# Altium schematic sheet Boost Converter 6V.SchDoc
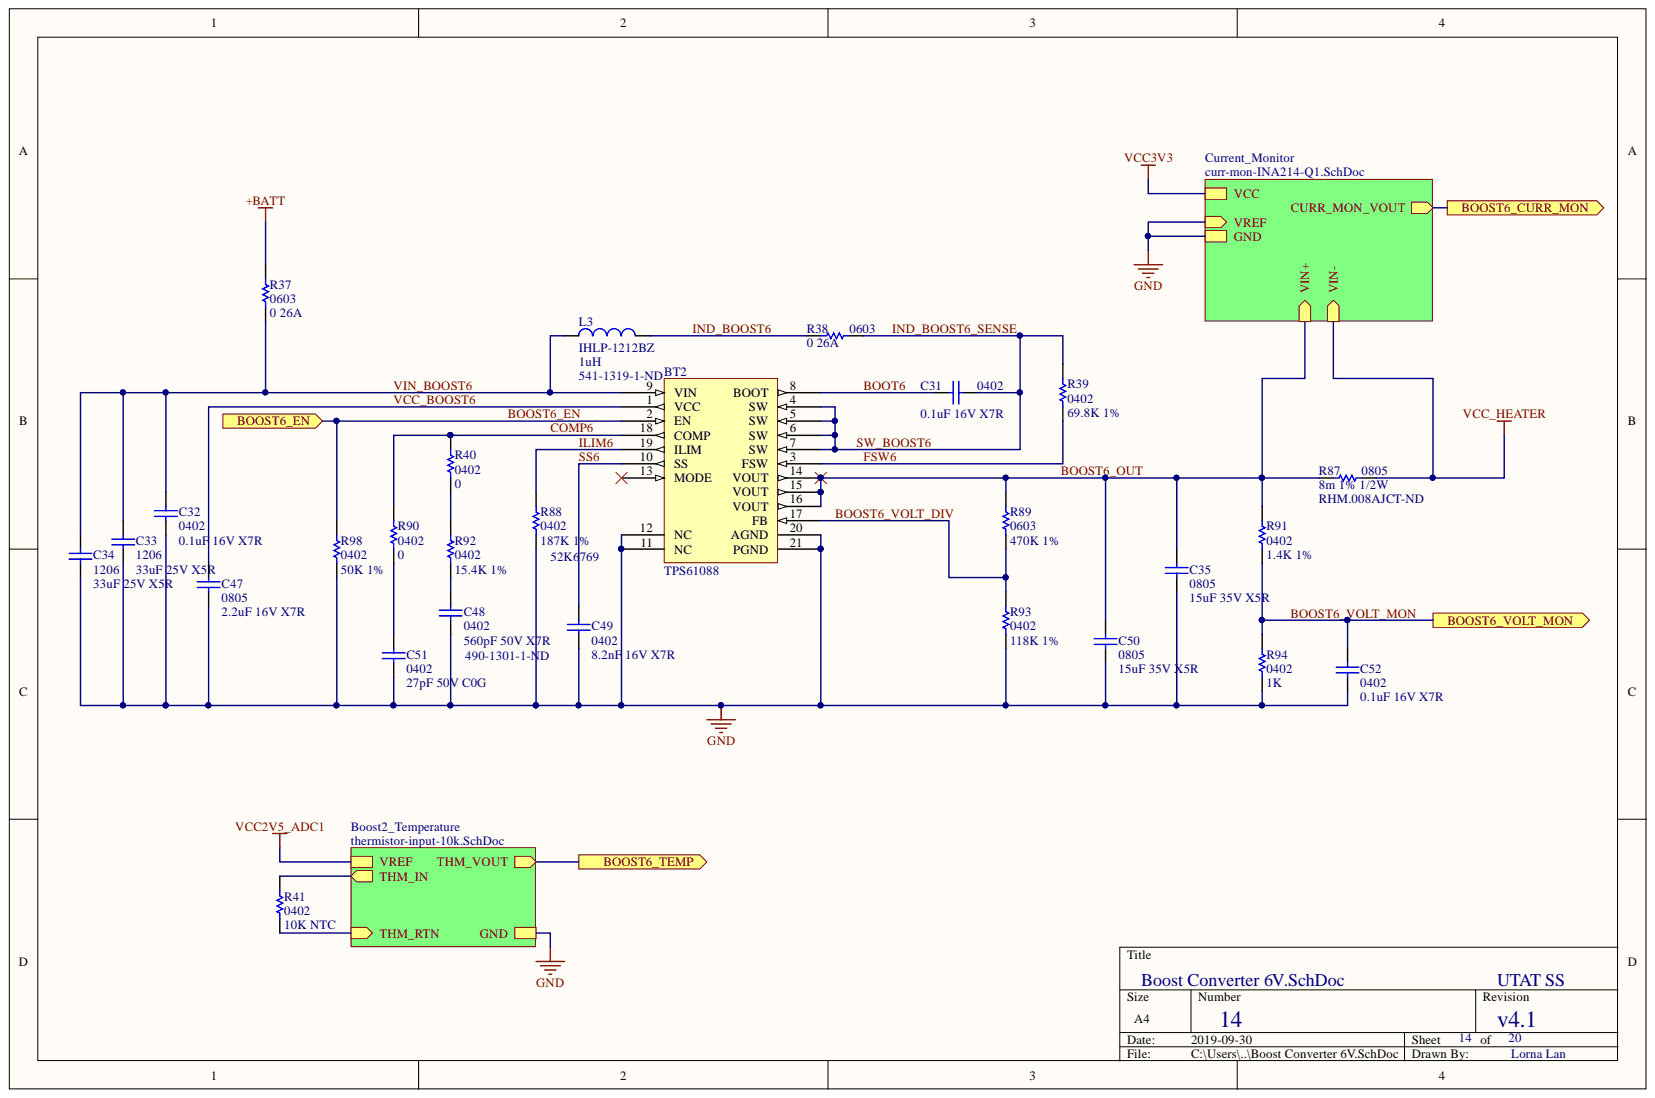
[diagram p0: Boost Converter 6V.SchDoc]

## Parts
BT2  TPS61088  [QFN50P450X350X100-21N]
C31  0402  value 0.1uF 16V X7R  [CAP0402R]
C32  0402  value 0.1uF 16V X7R  [CAP0402R]
C33  1206  value 33uF 25V X5R  [CAP1206R]
C34  1206  value 33uF 25V X5R  [CAP1206R]
C35  0805  value 15uF 35V X5R  [CAP0805R]
C47  0805  value 2.2uF 16V X7R  [CAP0805R]
C48  0402  value 560pF 50V X7R  Supplier Part Number 1: 490-1301-1-ND  [CAP0402R]
C49  0402  value 8.2nF 16V X7R  [CAP0402R]
C50  0805  value 15uF 35V X5R  [CAP0805R]
C51  0402  value 27pF 50V C0G  [CAP0402R]
C52  0402  value 0.1uF 16V X7R  [CAP0402R]
L3  IHLP-1212BZ  Inductance: 1uH  [IHLP-1212BZ]
R37  0603  value 0 26A  [RES0603R]
R38  0603  value 0 26A  [RES0603R]
R39  0402  value 69.8K 1%  [RES0402R]
R40  0402  value 0  [RES0402R]
R41  0402  value 10K NTC  [RES0402R]
R87  0805  value 8m 1% 1/2W  Supplier Part Number 1: RHM.008AJCT-ND  [RES0805R]
R88  0402  value 187K 1%  Supplier Part Number 1: 52K6769  [RES0402R]
R89  0603  value 470K 1%  [RES0603R]
R90  0402  value 0  [RES0402R]
R91  0402  value 1.4K 1%  [RES0402R]
R92  0402  value 15.4K 1%  [RES0402R]
R93  0402  value 118K 1%  [RES0402R]
R94  0402  value 1K  [RES0402R]
R98  0402  value 50K 1%  [RES0402R]

## Ports
BOOST6_CURR_MON, BOOST6_EN, BOOST6_TEMP, BOOST6_VOLT_MON

## Nets
+BATT: R37.2
GND: BT2.11(NC) BT2.12(NC) BT2.20(AGND) BT2.21(PGND) C32.1 C33.1 C34.1 C35.1 C47.1 C48.1 C49.1 C50.1 C51.1 C52.1 R88.1 R93.1 R94.1 R98.1
VCC_HEATER: R87.2
BOOST6_EN: BT2.2(EN) R98.2 ; port BOOST6_EN
BOOST6_OUT: BT2.14(VOUT) BT2.15(VOUT) BT2.16(VOUT) C35.2 C50.2 R87.1 R89.2 R91.2
BOOST6_VOLT_DIV: BT2.17(FB) R89.1 R93.2
BOOST6_VOLT_MON: C52.2 R91.1 R94.2 ; port BOOST6_VOLT_MON
BOOT6: BT2.8(BOOT) C31.1
COMP6: BT2.18(COMP) R40.2 R90.2
FSW6: BT2.3(FSW) R39.1
ILIM6: BT2.19(ILIM) R88.2
IND_BOOST6: L3.2 R38.1
IND_BOOST6_SENSE: BT2.4(SW) BT2.5(SW) BT2.6(SW) BT2.7(SW) C31.2 R38.2 R39.2
SS6: BT2.10(SS) C49.2
VCC_BOOST6: BT2.1(VCC) C47.2
VIN_BOOST6: BT2.9(VIN) C32.2 C33.2 C34.2 L3.1 R37.1
NetBT2_13: BT2.13(MODE)
NetC48_2: C48.2 R92.1
NetC51_2: C51.2 R90.1
NetR40_1: R40.1 R92.2
NetR41_1: R41.1
NetR41_2: R41.2
N00341: ; port BOOST6_CURR_MON
N00342: ; port BOOST6_TEMP
VCC2V5_ADC1:
VCC3V3:

## Library data of the parts
BT2 = TPS61088: Boost converter; Component Kind: Standard; Library Name: EPS.SchLib; Supplier 1: Digi-Key; Supplier Part Number 1: 296-42129-1-ND
C31 C49 C52 = CAP0402: 0402 capacitor; Component Kind: Standard; Signal Integrity: Cap; Simulation: CAP
  C49: Library Name: CAP0402.SchLib; Supplier 1: Digi-Key; Supplier Part Number 1: 490-6338-1-ND
  C31 C52: Library Name: heron-general.IntLib
C32 = CAP0402: 0402 capacitor; Value: 0.1uF 16V X7R; Component Kind: Standard; Signal Integrity: Cap; Simulation: CAP; Library Name: heron-general.IntLib
C33 C34 = CAP1206: 1206 capacitor; Value: 33uF 25V X5R; Component Kind: Standard; Signal Integrity: Cap; Simulation: CAP; Supplier 1: Digi-Key; Supplier Part Number 1: 445-8046-1-ND; Library Name: heron-general.IntLib
C35 = CAP0805: 0805 capacitor; Value: 15uF 35V X5R; Component Kind: Standard; Signal Integrity: Cap; Simulation: CAP; Supplier 1: Mouser; Supplier Part Number 1: 810-C2012X5R1V156M1C; Library Name: heron-general.IntLib
C47 C50 = CAP0805: 0805 capacitor; Component Kind: Standard; Signal Integrity: Cap; Simulation: CAP; Library Name: heron-general.IntLib
  C47: Supplier 1: Digi-Key; Supplier Part Number 1: 490-3906-1-ND
  C50: Supplier 1: Mouser; Supplier Part Number 1: 810-C2012X5R1V156M1C
C48 = CAP0402: 0402 capacitor; Value: 560pF 50V X7R; Component Kind: Standard; Signal Integrity: Cap; Simulation: CAP; Supplier 1: Digi-Key; Library Name: heron-general.IntLib
C51 = CAP0402: 0402 capacitor; Value: 27pF 50V C0G; Component Kind: Standard; Signal Integrity: Cap; Simulation: CAP; Supplier 1: Digi-Key; Supplier Part Number 1: 490-5869-1-ND; Library Name: heron-general.IntLib
L3 = IND_IHLP-1212BZ: 1µH Shielded Molded Inductor 5A 24mOhm Max Nonstandard; Manufacturer: Vishay Dale; Manufacturer Part Number: IHLP1212BZER1R0M11; Core Material: Metal,Composite; DC Resistance (DCR): 24mR; Inductance Tolerance: 20%; Saturation Current: 4.5A; Self Resonant Frequency: 75MHz; Test Frequency: 100kHz; Case/Package: 1212; Case Code (Imperial): 1212; Case Code (Metric): 3630; Component Kind: Standard; ComponentLink1Description: http://www.mouser.com/ds/2/427/lp12bz11-244380.pdf?src-supplier=Mouser; ComponentLink1URL: http://www.mouser.com/ds/2/427/lp12bz11-244380.pdf?src-supplier=Mouser; ComponentLink2Description: http://www.vishay.com/docs/34289/lp12bz11.pdf?src-supplier=Digi-Key; ComponentLink2URL: http://www.vishay.com/docs/34289/lp12bz11.pdf?src-supplier=Digi-Key; ComponentLink3Description: http://www.vishay.com/docs/34289/lp12bz11.pdf?src-supplier=Avnet; ComponentLink3URL: http://www.vishay.com/docs/34289/lp12bz11.pdf?src-supplier=Avnet; ComponentLink4Description: http://www.farnell.com/datasheets/652599.pdf?src-supplier=Element14; ComponentLink4URL: http://www.farnell.com/datasheets/652599.pdf?src-supplier=Element14; ComponentLink5Description: http://www.vishay.com/docs/34289/lp12bz11.pdf?src-supplier=Avnet+Europe; ComponentLink5URL: http://www.vishay.com/docs/34289/lp12bz11.pdf?src-supplier=Avnet+Europe; ComponentLink6Description: https://www.verical.com/server-webapp/html/getDatasheet?partID=180380&src-supplier=Verical; ComponentLink6URL: https://www.verical.com/server-webapp/html/getDatasheet?partID=180380&src-supplier=Verical; Contact Plating: Tin; Current Rating: 5A; Depth: 3.2mm; Height: 2mm; Height - Seated (Max): 0.079inch; Lead Free: Lead Free; Length: 3.6mm; Max DC Current: 5A; Max Operating Temperature: 125°C; Military Standard: Not; Min Operating Temperature: -55°C; Mount: Surface Mount; Number of Pins: 2; Package Quantity: 3000; Packaging: Tape and Reel; Pricing 1: 1=1.45, 10=1.346, 100=0.9729, 500=0.7452, 1000=0.6624 (USD); Radiation Hardening: No; REACH SVHC: Unknown; RMS Current (Irms): 5A; Shielding: Shielded; Stock 1: 196362; Supplier 1: Digi-Key; Supplier Part Number 1: 541-1319-1-ND; Termination: SMD/SMT; Tolerance: 20%; Width: 0.118inch; Library Name: heron-general.IntLib
R37 R38 R89 = RES0603: 0603 resistor; Component Kind: Standard; Signal Integrity: Res; Simulation: RESISTOR; Supplier 1: Digi-Key
  R37 R38: Supplier Part Number 1: 2019-TLRZ1JTTDCT-ND; Library Name: heron-general.IntLib
  R89: Library Name: RES0603.SchLib; Supplier Part Number 1: 311-470KHRCT-ND
R39 R41 R88 R91-R94 = RES0402: 0402 resistor; Component Kind: Standard; Signal Integrity: Res; Simulation: RESISTOR
  R39: Supplier 1: Digi-Key; Supplier Part Number 1: 541-69.8KLCT-ND
  R88: Supplier 1: Newark
  R91: Library Name: RES0402.SchLib
  R93: Library Name: RES0402.SchLib; Supplier 1: Digi-Key; Supplier Part Number 1: 541-118KLCT-ND
  R94: Library Name: RES0402.SchLib; Supplier 1: Digi-Key; Supplier Part Number 1: YAG1234CT-ND
  R39 R41 R88 R92: Library Name: heron-general.IntLib
R40 R90 = RES0402: 0402 resistor; Manufacturer: Panasonic; Manufacturer Part Number: ERJ-2GE0R00X; Max Power Dissipation: 100mW; Resistance: 0mR; Temperature Coefficient: 600ppm/°C; Tolerance: 5%; Voltage Rating: 50V; Case/Package: 0402; Case Code (Imperial): 0402; Case Code (Metric): 1005; Component Kind: Standard; ComponentLink1Description: http://industrial.panasonic.com/www-data/pdf/AOA0000/AOA0000CE1.pdf?src-supplier=Avnet; ComponentLink1URL: http://industrial.panasonic.com/www-data/pdf/AOA0000/AOA0000CE1.pdf?src-supplier=Avnet; ComponentLink2Description: http://www.alliedelec.com/m/d/51cd0790071e1666bdb9d00c57fcf960.pdf?src-supplier=Allied+Electronics; ComponentLink2URL: http://www.alliedelec.com/m/d/51cd0790071e1666bdb9d00c57fcf960.pdf?src-supplier=Allied+Electronics; ComponentLink3Description: http://industrial.panasonic.com/www-data/pdf/AOA0000/AOA0000CE1.pdf?src-supplier=Avnet+Europe; ComponentLink3URL: http://industrial.panasonic.com/www-data/pdf/AOA0000/AOA0000CE1.pdf?src-supplier=Avnet+Europe; ComponentLink4Description: http://www.farnell.com/datasheets/2059623.pdf?src-supplier=Farnell; ComponentLink4URL: http://www.farnell.com/datasheets/2059623.pdf?src-supplier=Farnell; ComponentLink5Description: http://www.farnell.com/datasheets/2059623.pdf?src-supplier=Element14; ComponentLink5URL: http://www.farnell.com/datasheets/2059623.pdf?src-supplier=Element14; ComponentLink6Description: http://www.farnell.com/datasheets/1678796.pdf?src-supplier=Element14; ComponentLink6URL: http://www.farnell.com/datasheets/1678796.pdf?src-supplier=Element14; Composition: Thick Film; Contact Plating: Tin; Depth: 0.5mm; Features: Automotive AEC-Q200; Height: 0.35mm; Lead Free: Lead Free; Length: 1mm; Max Operating Temperature: 155°C; Military Standard: Not; Min Operating Temperature: -55°C; Mount: Surface Mount; Number of Terminations: 2; Package Quantity: 1; Packaging: Tape and Reel; Power Rating: 100mW; Pricing 1: 1=0.1, 10=0.03, 25=0.0216, 50=0.0164, 100=0.0121, 250=0.00924, 500=0.0074, 1000=0.00545, 5000=0.00391 (USD); Radiation Hardening: No; REACH SVHC: No SVHC; Signal Integrity: Res; Simulation: RESISTOR; Stock 1: 47740000; Supplier 1: Digi-Key; Supplier Part Number 1: P0.0JCT-ND; Termination: SMD/SMT; Voltage Rating (DC): 50V; Width: 0.02inch; Library Name: heron-general.IntLib
R87 = RES0805: 0805 resistor; Component Kind: Standard; Library Name: RES0805.SchLib; Signal Integrity: Res; Simulation: RESISTOR; Supplier 1: Digi-Key
R98 = RES0402: 0402 resistor; Value: 50K 1%; Component Kind: Standard; Signal Integrity: Res; Simulation: RESISTOR; Library Name: heron-general.IntLib
